# Altium schematic sheet Schematic 1.SchDoc
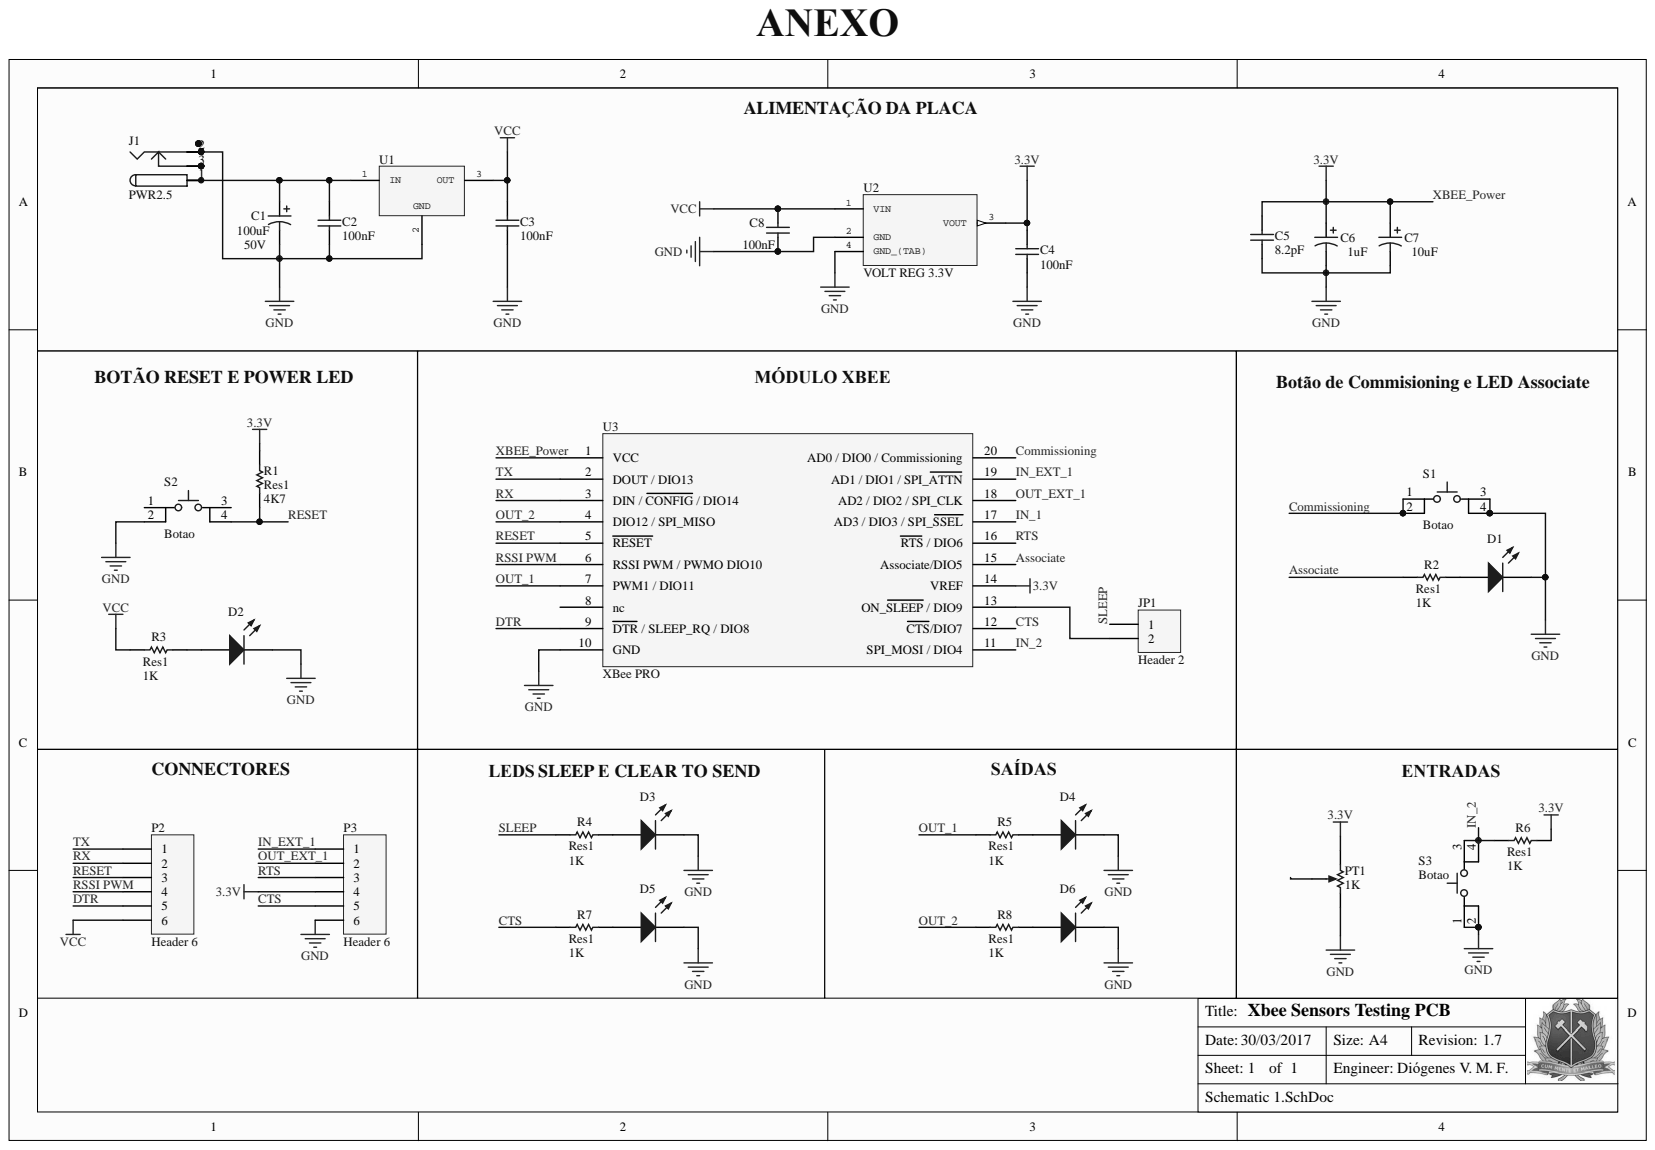
[diagram p0: Schematic 1.SchDoc]

## Parts
C1  value 100uF  [CAPACITOR_8mm]  (hidden comment: Cap Pol1)
C2  100nF  [CAP0805R]
C3  100nF  [CAP0805R]
C4  100nF  [CAP0805R]
C5  8.2pF  [CAP0603R]
C6  value 1uF  [CAP_ELETROLITIC_5mm]  (hidden comment: Cap Pol1)
C7  value 10uF  [CAP_ELETROLITIC_5mm]  (hidden comment: Cap Pol1)
C8  100nF  [CAP0805R]
D1  LED0  [LED_3mm_RED]  (value not drawn)
D2  LED0  [LED_3mm_RED]  (value not drawn)
D3  LED0  [LED_3mm_YELLOW]  (value not drawn)
D4  LED0  [LED_3mm_GREEN]  (value not drawn)
D5  LED0  [LED_3mm_YELLOW]  (value not drawn)
D6  LED0  [LED_3mm_GREEN]  (value not drawn)
J1  PWR2.5  [DC_Connector]
JP1  Header 2  [HEADER_2_PINS]
P2  Header 6  [Header_6_Pins]
P3  Header 6  [Header_6_Pins]
PT1  value 1K  [POTENCIOMETRO_3386]  (hidden comment: RPot)
R1  Res1  value 4K7  [RES0805R]
R2  Res1  value 1K  [RES0805R]
R3  Res1  value 1K  [RES0805R]
R4  Res1  [RES0805R]
R5  Res1  [RES0805R]
R6  Res1  value 1K  [RES0805R]
R7  Res1  value 1K  [RES0805R]
R8  Res1  value 1K  [RES0805R]
S1  Botao  [PushButton_short]
S2  Botao  [PushButton_short]
S3  Botao  [PushButton_short]
U1  Voltage Regulator  [TO-220]  (value not drawn)
U2  VOLT REG 3.3V  [SOT230P700X180-4N]
U3  XBee PRO  [XBee PRO]

## Nets
GND: C1.2 C2.1 C3.1 C4.1 C5.1 C6.2 C7.2 C8.1 D1.2 D2.2 D3.2 D4.2 D5.2 D6.2 J1.2 J1.2A J1.2B P3.6 PT1.3 S1.3 S1.4 S2.2 S3.1 S3.2 U1.2 U2.2 U2.4 U3.10
VCC: C3.2 C8.2 P2.6 R3.1 U1.3 U2.1
ASSOCIATE: R2.1 U3.15
COMMISSIONING: S1.1 S1.2 U3.20
CTS: P3.5 R7.1 U3.12
DTR: P2.5 U3.9
IN_1: U3.17
IN_2: R6.1 S3.3 S3.4 U3.11
IN_EXT_1: P3.1 U3.19
OUT_1: R5.1 U3.7
OUT_2: R8.1 U3.4
OUT_EXT_1: P3.2 U3.18
RESET: P2.3 R1.1 S2.4 U3.5
RSSI PWM: P2.4 U3.6
RTS: P3.3 U3.16
RX: P2.2 U3.3
SLEEP: JP1.1 R4.1
TX: P2.1 U3.2
XBEE_POWER: C4.2 C5.2 C6.1 C7.1 P3.4 PT1.1 R1.2 R6.2 U2.3 U3.1 U3.14
NetC1_1: C1.1 C2.2 J1.1 J1.1A J1.1B U1.1
NetD1_1: D1.1 R2.2
NetD2_1: D2.1 R3.2
NetD3_1: D3.1 R4.2
NetD4_1: D4.1 R5.2
NetD5_1: D5.1 R7.2
NetD6_1: D6.1 R8.2
NetJ1_3: J1.3 J1.3A J1.3B
NetJP1_2: JP1.2 U3.13

Not connected: PT1 2; S2 1 3; U3 8

## Library data of the parts
C1 = Cap Pol1: Polarized Capacitor (Radial); Voltage: 50V; Component Kind: Standard; LatestRevisionDate: 17-Jul-2002; LatestRevisionNote: Re-released for DXP Platform.; Library Name: Miscellaneous Devices.IntLib; PackageDescription: Polarized Capacitor (Radial); 2 Leads; PackageReference: RB7.6-15; Published: 8-Jun-2000; Signal Integrity: Cap Pol; Simulation: CAP; Publisher: Altium Limited
C2-C5 C8 = Cap: Capacitor; Component Kind: Standard; LatestRevisionDate: 17-Jul-2002; LatestRevisionNote: Re-released for DXP Platform.; Library Name: Miscellaneous Devices.IntLib; PackageDescription: Radial Cap, Thru-Hole; 2 Leads; 0.3 in Pin Spacing; PackageReference: RAD-0.3; Published: 8-Jun-2000; Signal Integrity: Cap; Simulation: CAP; Publisher: Altium Limited
  C2-C4 C8: Value: 100nF
  C5: Value: 8.2pF
C6 = Cap Pol1: Polarized Capacitor (Radial); Value: 1uF; Component Kind: Standard; LatestRevisionDate: 17-Jul-2002; LatestRevisionNote: Re-released for DXP Platform.; Library Name: Miscellaneous Devices.IntLib; PackageDescription: Polarized Capacitor (Radial); 2 Leads; PackageReference: RB7.6-15; Published: 8-Jun-2000; Signal Integrity: Cap Pol; Simulation: CAP; Publisher: Altium Limited
C7 = Cap Pol1: Polarized Capacitor (Radial); Component Kind: Standard; LatestRevisionDate: 17-Jul-2002; LatestRevisionNote: Re-released for DXP Platform.; Library Name: Miscellaneous Devices.IntLib; PackageDescription: Polarized Capacitor (Radial); 2 Leads; PackageReference: RB7.6-15; Published: 8-Jun-2000; Signal Integrity: Cap Pol; Simulation: CAP; Publisher: Altium Limited
D1-D6 = LED0: Typical INFRARED GaAs LED; Component Kind: Standard; LatestRevisionDate: 08-Jul-2005; LatestRevisionNote: Mech Layer 1 removed.; Library Name: Miscellaneous Devices.IntLib; PackageDescription: LED; 2 Leads; PackageReference: LED-0; Published: 8-Jun-2000; Simulation: LED0; Publisher: Altium Limited
J1 = PWR2.5: Low Voltage Power Supply Connector; Component Kind: Standard; ComponentLink1Description: Manufacturer Link; ComponentLink1URL: http://domino.kycon.com/internet/Products.html; DatasheetDocument: 09-May-2002; Library Name: Miscellaneous Connectors.IntLib; Published: 17-Dec-2003; Signal Integrity: Connector; Publisher: Altium Limited
JP1 = Header 2: Header, 2-Pin; Component Kind: Standard; LatestRevisionDate: 17-Jul-2002; LatestRevisionNote: Re-released for DXP Platform.; Library Name: Miscellaneous Connectors.IntLib; Signal Integrity: Connector; Publisher: Altium Limited
P2 P3 = Header 6: Header, 6-Pin; Component Kind: Standard; LatestRevisionDate: 17-Jul-2002; LatestRevisionNote: Re-released for DXP Platform.; Library Name: Miscellaneous Connectors.IntLib; Signal Integrity: Connector; Publisher: Altium Limited
PT1 = RPot: Potentiometer; Set Position: 0.5; Sim Note: Enter the Resistance value and the set point into parameter fields.; Component Kind: Standard; LatestRevisionDate: 17-Jul-2002; LatestRevisionNote: Re-released for DXP Platform.; Library Name: Miscellaneous Devices.IntLib; PackageDescription: Variable Resistor, Thru-Hole; 3 Leads; PackageReference: VR5; Published: 8-Jun-2000; Simulation: POT; Publisher: Altium Limited
R1-R3 R7 R8 = Res1: Resistor; Component Kind: Standard; LatestRevisionDate: 17-Jul-2002; LatestRevisionNote: Re-released for DXP Platform.; Library Name: Miscellaneous Devices.IntLib; PackageDescription: Axial Device, Thru-Hole; 2 Leads; 0.3 in Pin Spacing; PackageReference: AXIAL-0.3; Published: 8-Jun-2000; Signal Integrity: Res; Simulation: RESISTOR; Publisher: Altium Limited
R4-R6 = Res1: Resistor; Value: 1K; Component Kind: Standard; LatestRevisionDate: 17-Jul-2002; LatestRevisionNote: Re-released for DXP Platform.; Library Name: Miscellaneous Devices.IntLib; PackageDescription: Axial Device, Thru-Hole; 2 Leads; 0.3 in Pin Spacing; PackageReference: AXIAL-0.3; Published: 8-Jun-2000; Signal Integrity: Res; Simulation: RESISTOR; Publisher: Altium Limited
U1 = LM7805: Component Kind: Standard; Library Name: LM7805.SchLib
U2 = LM2937IMP-5.0: Component Kind: Standard; Library Name: LM2937IMP-5.SCHLIB
S1-S3 = Botao: Component Kind: Standard; Library Name: Push_Button.SchLib
U3 = XBee PRO: Component Kind: Standard; Library Name: XBEE_MODULE.SchLib
